# Altium schematic sheet 1_CPUs_rC0.SchDoc
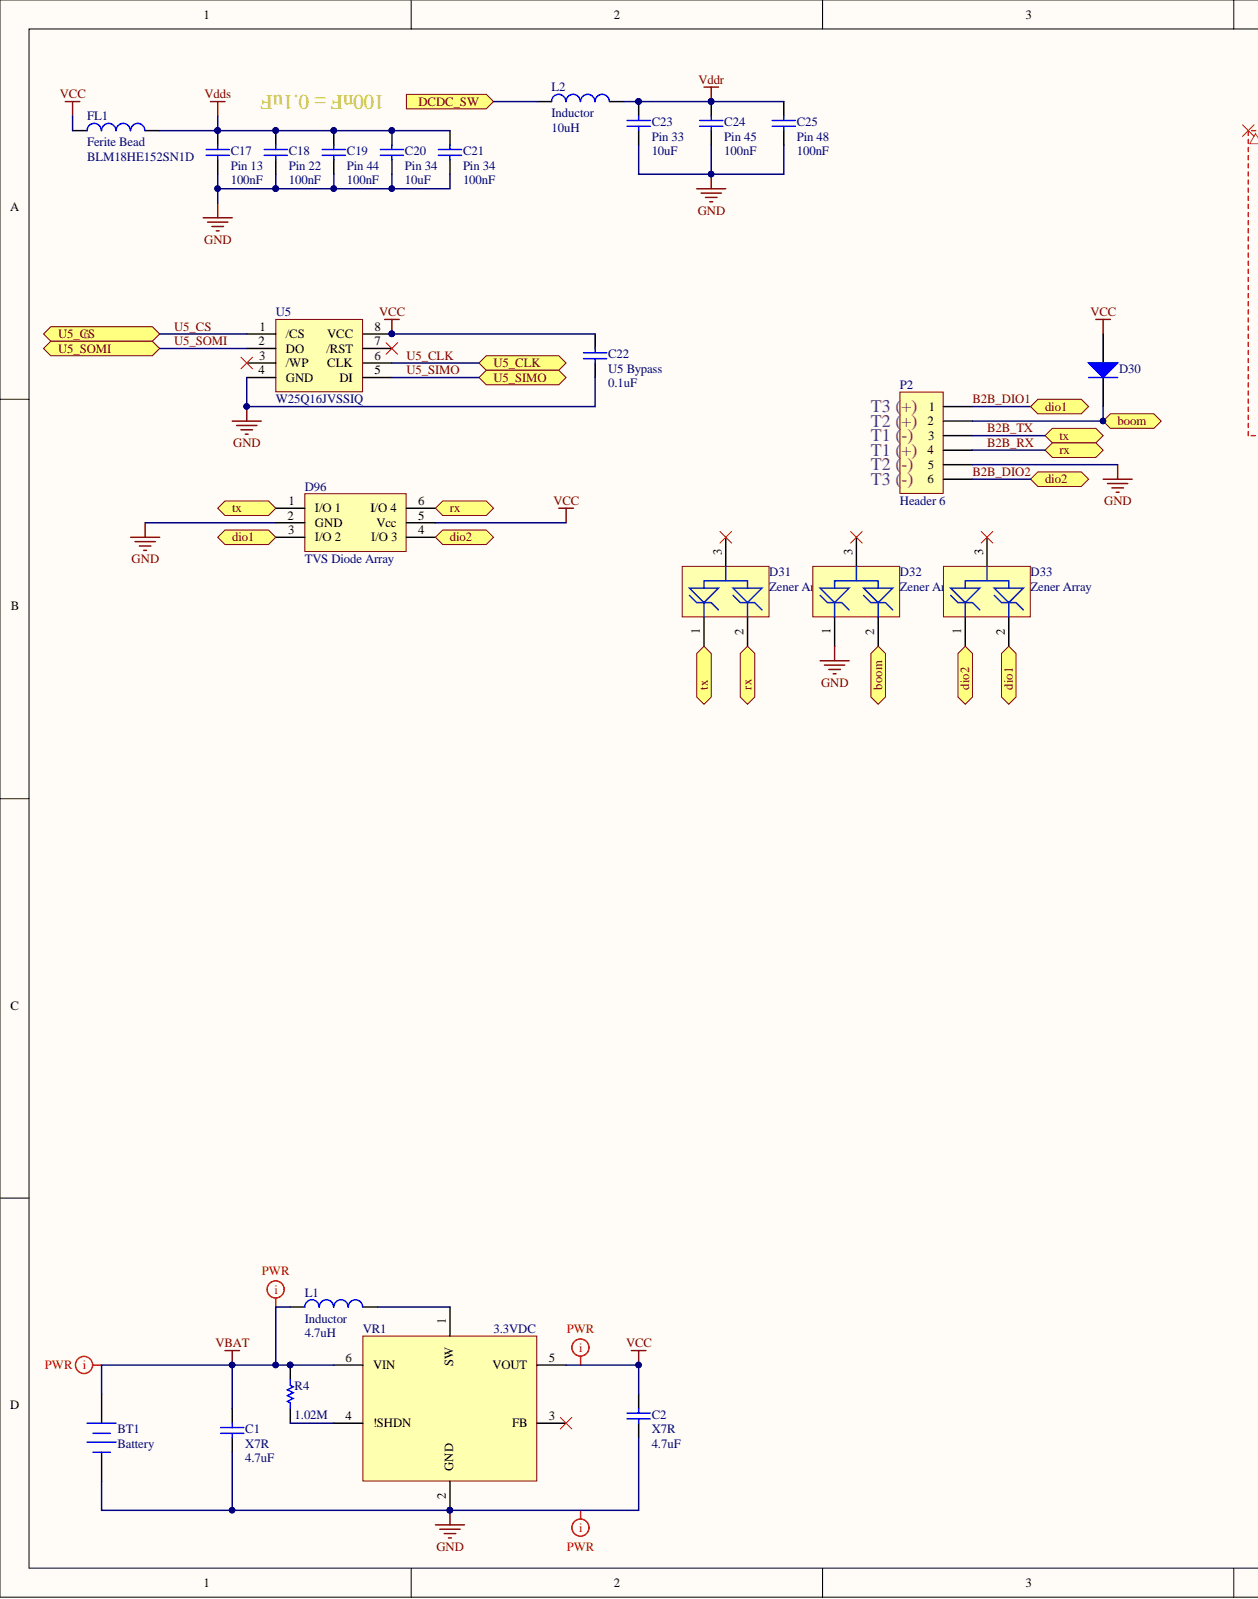
[diagram p0: 1_CPUs_rC0.SchDoc, left]
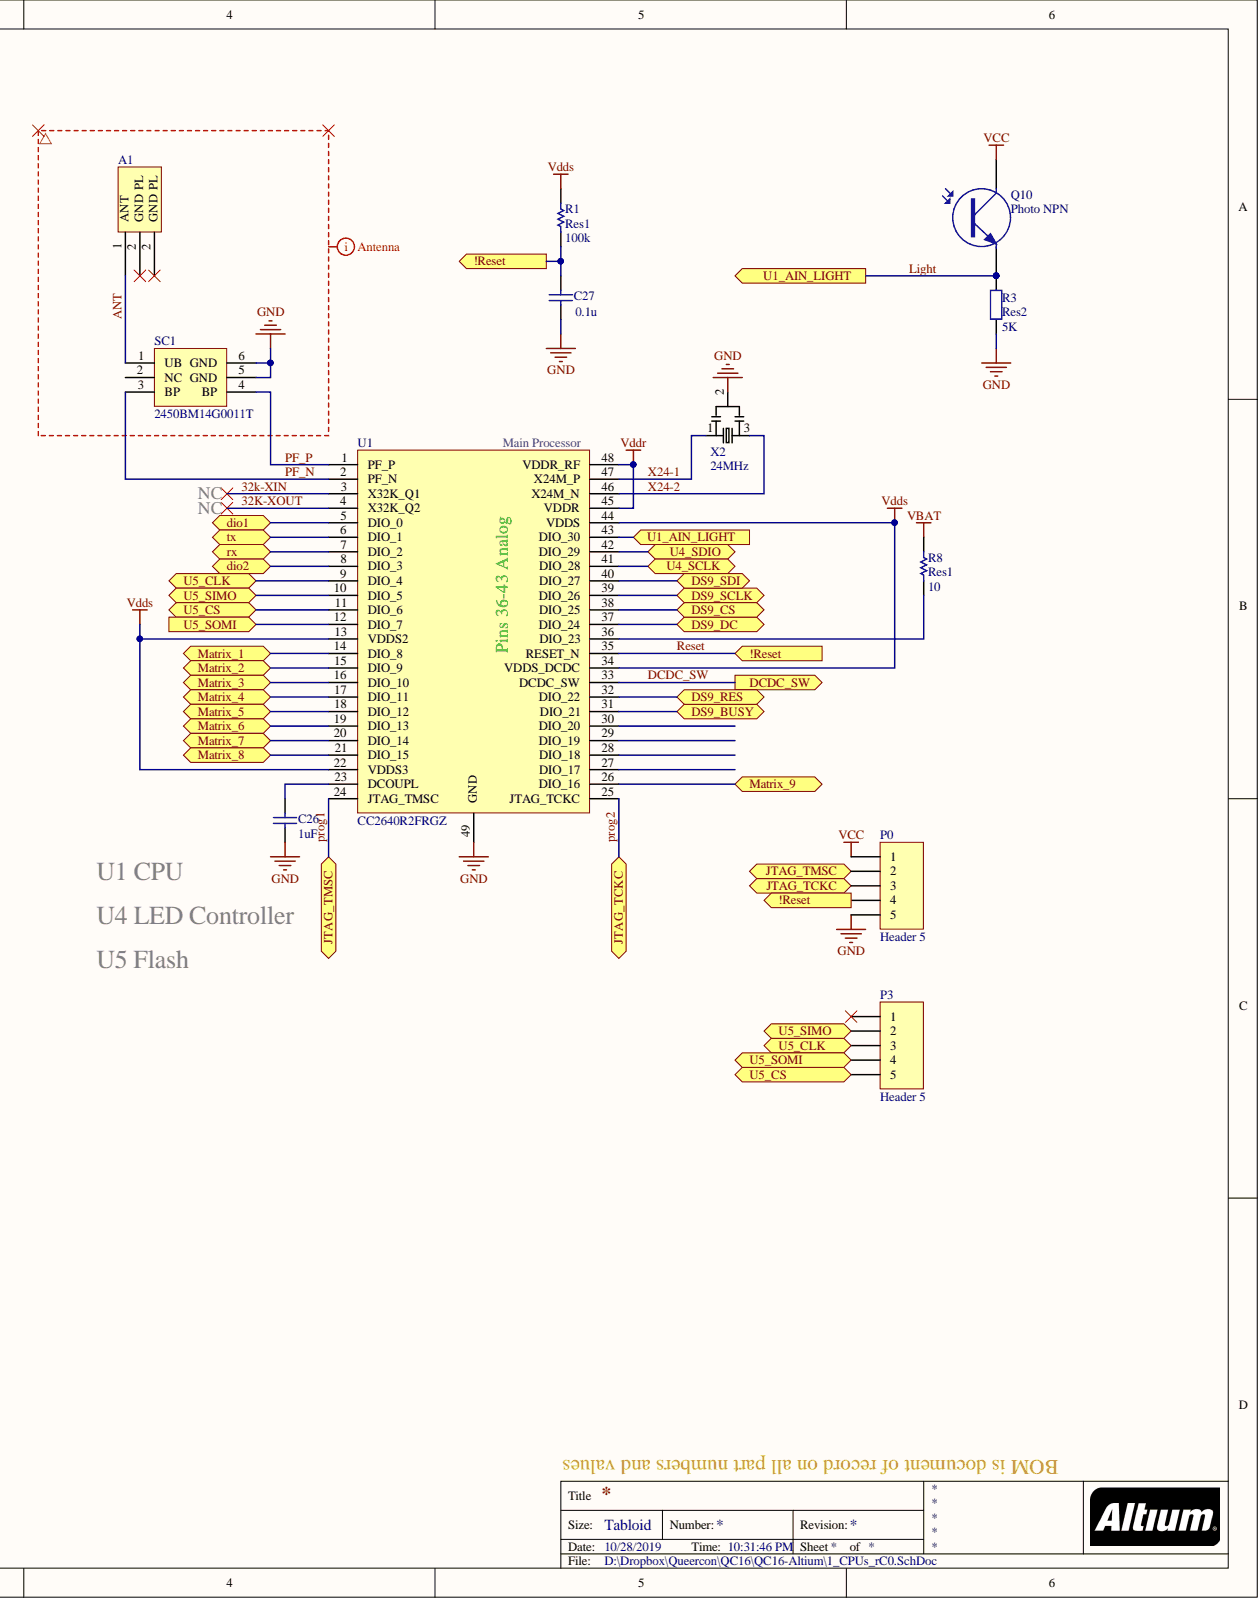
[diagram p1: 1_CPUs_rC0.SchDoc, right]

## Parts
A1  ANT  [CHIP_ANTENNA]
BT1  Battery  [BATT2AA]
C1  X7R  value 4.7uF  [CAPC3216(1206)]
C2  X7R  value 4.7uF  [CAPC3216(1206)]
C17  Pin 13  value 100nF  [CAPC1608(0603)]
C18  Pin 22  value 100nF  [CAPC1608(0603)]
C19  Pin 44  value 100nF  [CAPC1608(0603)]
C20  Pin 34  value 10uF  [RESC1105(0402)]
C21  Pin 34  value 100nF  [CAPC1608(0603)]
C22  U5 Bypass  value 0.1uF  [CAPC1608(0603)]
C23  Pin 33  value 10uF  [RESC1105(0402)]
C24  Pin 45  value 100nF  [CAPC1608(0603)]
C25  Pin 48  value 100nF  [CAPC1608(0603)]
C26  value 1uF  [CAPC1608(0603)]  (hidden comment: Decoupling)
C27  value 0.1u  [CAPC1608(0603)]
D30  Diode 1N914  [SOD-123F]  (value not drawn)
D31  Zener Array  [SOT95P148X115-3N]
D32  Zener Array  [SOT95P148X115-3N]
D33  Zener Array  [SOT95P148X115-3N]
D96  TVS Diode Array  [SOT65P210X100-6N]
FL1  Ferite Bead  value BLM18HE152SN1D  [RESC1608(0603)]
L1  Inductor  value 4.7uH  [6-0805_N]
L2  Inductor  value 10uH  [INDC2518(1007)]
P0  Header 5  [Prog 2mm 5]
P2  Header 6  [RJ25 Molex SMT]
P3  Header 5  [Prog 2mm 5]
Q10  Photo NPN  [Photo Plcc2]
R1  Res1  value 100k  [RESC1608(0603)]
R3  Res2  value 5K  [RESC1608(0603)]
R4  value 1.02M  [RESC1608(0603)]  (hidden comment: Res1)
R8  Res1  value 10  [RESC1608(0603)]
SC1  2450BM14G0011T  [MatchingChip]
U1  CC2640R2FRGZ  [QFN50P700X700X100_HS-49N]
U5  W25Q16JVSSIQ  [SOIC-8 208mil]
VR1  3.3VDC  [SOT95P280X145-6N]
X2  24MHz  [TSX3225]

## Ports
!Reset, boom, DCDC_SW, dio1, dio2, DS9_BUSY, DS9_CS, DS9_DC, DS9_RES, DS9_SCLK, DS9_SDI, JTAG_TCKC, JTAG_TMSC, Matrix_1, Matrix_2, Matrix_3, Matrix_4, Matrix_5, Matrix_6, Matrix_7, Matrix_8, Matrix_9, rx, tx, U1_AIN_LIGHT, U4_SCLK, U4_SDIO, U5_CLK, U5_CS, U5_SIMO, U5_SOMI

## Nets
GND: BT1.2 C1.1 C2.1 C17.1 C18.1 C19.1 C20.1 C21.1 C22.1 C23.1 C24.1 C25.1 C26.1 C27.1 D32.1 D96.2(GND) P0.5 P2.5 R3.1 SC1.5(GND) SC1.6(GND) U1.49(GND) U5.4(GND) VR1.2(GND) X2.2
VBAT: BT1.1 C1.2 L1.1 R4.2 R8.1 VR1.6(VIN)
VCC: C2.2 C22.2 D30.1 D96.5(Vcc) FL1.1 P0.1 Q10.1 U5.8(VCC) VR1.5(VOUT)
Vddr: C23.2 C24.2 C25.2 L2.2 U1.45(VDDR) U1.48(VDDR_RF)
Vdds: C17.2 C18.2 C19.2 C20.2 C21.2 FL1.2 R1.1 U1.13(VDDS2) U1.22(VDDS3) U1.34(VDDS_DCDC) U1.44(VDDS)
32k-XIN: U1.3(X32K_Q1)
32K-XOUT: U1.4(X32K_Q2)
ANT: A1.1 SC1.1(UB)
B2B_DIO1: D33.2 D96.3(I/O_2) P2.1 U1.5(DIO_0) ; port dio1
B2B_DIO2: D33.1 D96.4(I/O_3) P2.6 U1.8(DIO_3) ; port dio2
B2B_RX: D31.2 D96.6(I/O_4) P2.4 U1.7(DIO_2) ; port rx
B2B_TX: D31.1 D96.1(I/O_1) P2.3 U1.6(DIO_1) ; port tx
boom: D30.2 D32.2 P2.2 ; port boom
DCDC_SW: L2.1 U1.33(DCDC_SW) ; port DCDC_SW
DS9_BUSY: U1.31(DIO_21) ; port DS9_BUSY
DS9_CS: U1.38(DIO_25) ; port DS9_CS
DS9_DC: U1.37(DIO_24) ; port DS9_DC
DS9_RES: U1.32(DIO_22) ; port DS9_RES
DS9_SCLK: U1.39(DIO_26) ; port DS9_SCLK
DS9_SDI: U1.40(DIO_27) ; port DS9_SDI
Light: Q10.3 R3.2 U1.43(DIO_30) ; port U1_AIN_LIGHT
Matrix_1: U1.14(DIO_8) ; port Matrix_1
Matrix_2: U1.15(DIO_9) ; port Matrix_2
Matrix_3: U1.16(DIO_10) ; port Matrix_3
Matrix_4: U1.17(DIO_11) ; port Matrix_4
Matrix_5: U1.18(DIO_12) ; port Matrix_5
Matrix_6: U1.19(DIO_13) ; port Matrix_6
Matrix_7: U1.20(DIO_14) ; port Matrix_7
Matrix_8: U1.21(DIO_15) ; port Matrix_8
Matrix_9: U1.26(DIO_16) ; port Matrix_9
PF_N: SC1.3(BP) U1.2(PF_N)
PF_P: SC1.4(BP) U1.1(PF_P)
prog1: P0.2 U1.24(JTAG_TMSC) ; port JTAG_TMSC
prog2: P0.3 U1.25(JTAG_TCKC) ; port JTAG_TCKC
Reset: C27.2 P0.4 R1.2 U1.35(RESET_N) ; port !Reset
U4_SCLK: U1.41(DIO_28) ; port U4_SCLK
U4_SDIO: U1.42(DIO_29) ; port U4_SDIO
U5_CLK: P3.3 U1.9(DIO_4) U5.6(CLK) ; port U5_CLK
U5_CS: P3.5 U1.11(DIO_6) U5.1(/CS) ; port U5_CS
U5_SIMO: P3.2 U1.10(DIO_5) U5.5(DI) ; port U5_SIMO
U5_SOMI: P3.4 U1.12(DIO_7) U5.2(DO) ; port U5_SOMI
X24-1: U1.47(X24M_P) X2.1
X24-2: U1.46(X24M_N) X2.3
NetC26_2: C26.2 U1.23(DCOUPL)
NetL1_2: L1.2 VR1.1(SW)
NetR4_1: R4.1 VR1.4(!SHDN)
NetR8_2: R8.2 U1.36(DIO_23)
N00007:

Not connected: A1 2; D31 3; D32 3; D33 3; P3 1; SC1 2(NC); U1 27(DIO_17) 28(DIO_18) 29(DIO_19) 30(DIO_20); U5 3(/WP) 7(/RST); VR1 3(FB)

## Library data of the parts
BT1 = Battery: Multicell Battery; Component Kind: Standard; LatestRevisionDate: 17-Jul-2002; LatestRevisionNote: Re-released for DXP Platform.; Library Name: Miscellaneous Devices.IntLib; PackageDescription: Battery; 2 Leads; PackageReference: BAT-2; Published: 24-Mar-1999; Publisher: Altium Limited
C1 C2 C17-C27 = Cap: Capacitor; Component Kind: Standard; LatestRevisionDate: 17-Jul-2002; LatestRevisionNote: Re-released for DXP Platform.; Library Name: Miscellaneous Devices.IntLib; PackageDescription: Radial Cap, Thru-Hole; 2 Leads; 0.3 in Pin Spacing; PackageReference: RAD-0.3; Published: 8-Jun-2000; Signal Integrity: Cap; Simulation: CAP; Publisher: Altium Limited
D30 = Diode 1N914: High Conductance Fast Diode; Code_JEDEC: DO-204-AH; Component Kind: Standard; ComponentLink1Description: Manufacturer Link; ComponentLink1URL: http://www.fairchildsemi.com/; ComponentLink2Description: Datasheet; ComponentLink2URL: http://www.fairchildsemi.com/ds/1N%2F1N4448.pdf; DatasheetVersion: 1997; LatestRevisionDate: 17-Jul-2002; LatestRevisionNote: Re-released for DXP Platform.; Library Name: Miscellaneous Devices.IntLib; PackageDescription: Diode, Axial; Body 3.8 x 1.9 mm (LxDia. max), Lead Dia. 0.53 mm (max); PackageReference: DO-35; Published: 8-Jun-2000; Signal Integrity: 1N914; Simulation: 1N914; Publisher: Altium Limited
D96 = TVS_DIODE_ARRAY: Component Kind: Standard; Library Name: QC12.SchLib
FL1 = Inductor: Code_IEC: 1005; Value: BLM18HE152SN1D; Code_JEITA: 0402; Component Kind: Standard; Description: Inductor; LatestRevisionDate: 29-May-2009; LatestRevisionNote: IPC-7351 Footprint Added.; Library Name: Miscellaneous Devices.IntLib; PackageDescription: Chip Inductor; PackageReference: 0402-A; Published: 8-Jun-2000; Signal Integrity: Inductor; Simulation: INDUCTOR; Publisher: Altium Limited
L1 = Inductor: Code_IEC: 1005; Value: 4.7uH; Code_JEITA: 0402; Component Kind: Standard; Description: Inductor; LatestRevisionDate: 29-May-2009; LatestRevisionNote: IPC-7351 Footprint Added.; Library Name: Miscellaneous Devices.IntLib; PackageDescription: Chip Inductor; PackageReference: 0402-A; Published: 8-Jun-2000; Signal Integrity: Inductor; Simulation: INDUCTOR; Publisher: Altium Limited
L2 = Inductor: Code_IEC: 1005; Code_JEITA: 0402; Component Kind: Standard; Description: Inductor; LatestRevisionDate: 29-May-2009; LatestRevisionNote: IPC-7351 Footprint Added.; Library Name: Miscellaneous Devices.IntLib; PackageDescription: Chip Inductor; PackageReference: 0402-A; Published: 8-Jun-2000; Signal Integrity: Inductor; Simulation: INDUCTOR; Publisher: Altium Limited
P0 P3 = Header 5: Header, 5-Pin; Component Kind: Standard; LatestRevisionDate: 17-Jul-2002; LatestRevisionNote: Re-released for DXP Platform.; Library Name: Miscellaneous Connectors.IntLib; Signal Integrity: Connector; Publisher: Altium Limited
P2 = Header 6: Header, 6-Pin; Component Kind: Standard; LatestRevisionDate: 17-Jul-2002; LatestRevisionNote: Re-released for DXP Platform.; Library Name: Miscellaneous Connectors.IntLib; Signal Integrity: Connector; Publisher: Altium Limited
Q10 = Photo NPN: NPN Phototransistor; Code_JEDEC: TO-220-AC; Component Kind: Standard; LatestRevisionDate: 17-Jul-2002; LatestRevisionNote: Re-released for DXP Platform.; Library Name: Miscellaneous Devices.IntLib; PackageReference: TO-220_A; Published: 24-Mar-1999; Publisher: Altium Limited
R1 R4 R8 = Res1: Resistor; Component Kind: Standard; LatestRevisionDate: 17-Jul-2002; LatestRevisionNote: Re-released for DXP Platform.; Library Name: Miscellaneous Devices.IntLib; PackageDescription: Axial Device, Thru-Hole; 2 Leads; 0.3 in Pin Spacing; PackageReference: AXIAL-0.3; Published: 8-Jun-2000; Signal Integrity: Res; Simulation: RESISTOR; Publisher: Altium Limited
R3 = Res2: Resistor; Component Kind: Standard; LatestRevisionDate: 17-Jul-2002; LatestRevisionNote: Re-released for DXP Platform.; Library Name: Miscellaneous Devices.IntLib; PackageReference: AXIAL-0.4; Published: 8-Jun-2000; Signal Integrity: Res; Simulation: RESISTOR; Publisher: Altium Limited
SC1 = MatchingChip: Component Kind: Standard; Library Name: Lib_2017.SchLib
U1 = Ti CC2640F128RGZ: Component Kind: Standard; Library Name: Lib_2017.SchLib
U5 = Winbound Flash: Component Kind: Standard; Library Name: Lib_2019.SchLib
VR1 = Skyworks AAT1217ICA-3.3-T1: Component Kind: Standard; Library Name: QC12.SchLib
X2 = X908-ND: Manufacturer: ECS; Component Kind: Standard; Library Name: QC10.SchLib; PackageReference: 5x10mm; PN: X908-ND
A1 = ANT: Component Kind: Standard; Library Name: QC10.SchLib
D31-D33 = Zener Array: Component Kind: Standard; Library Name: Lib_2019.SchLib
